# Altium schematic sheet HLib-Comm-CAN_r1.SchDoc
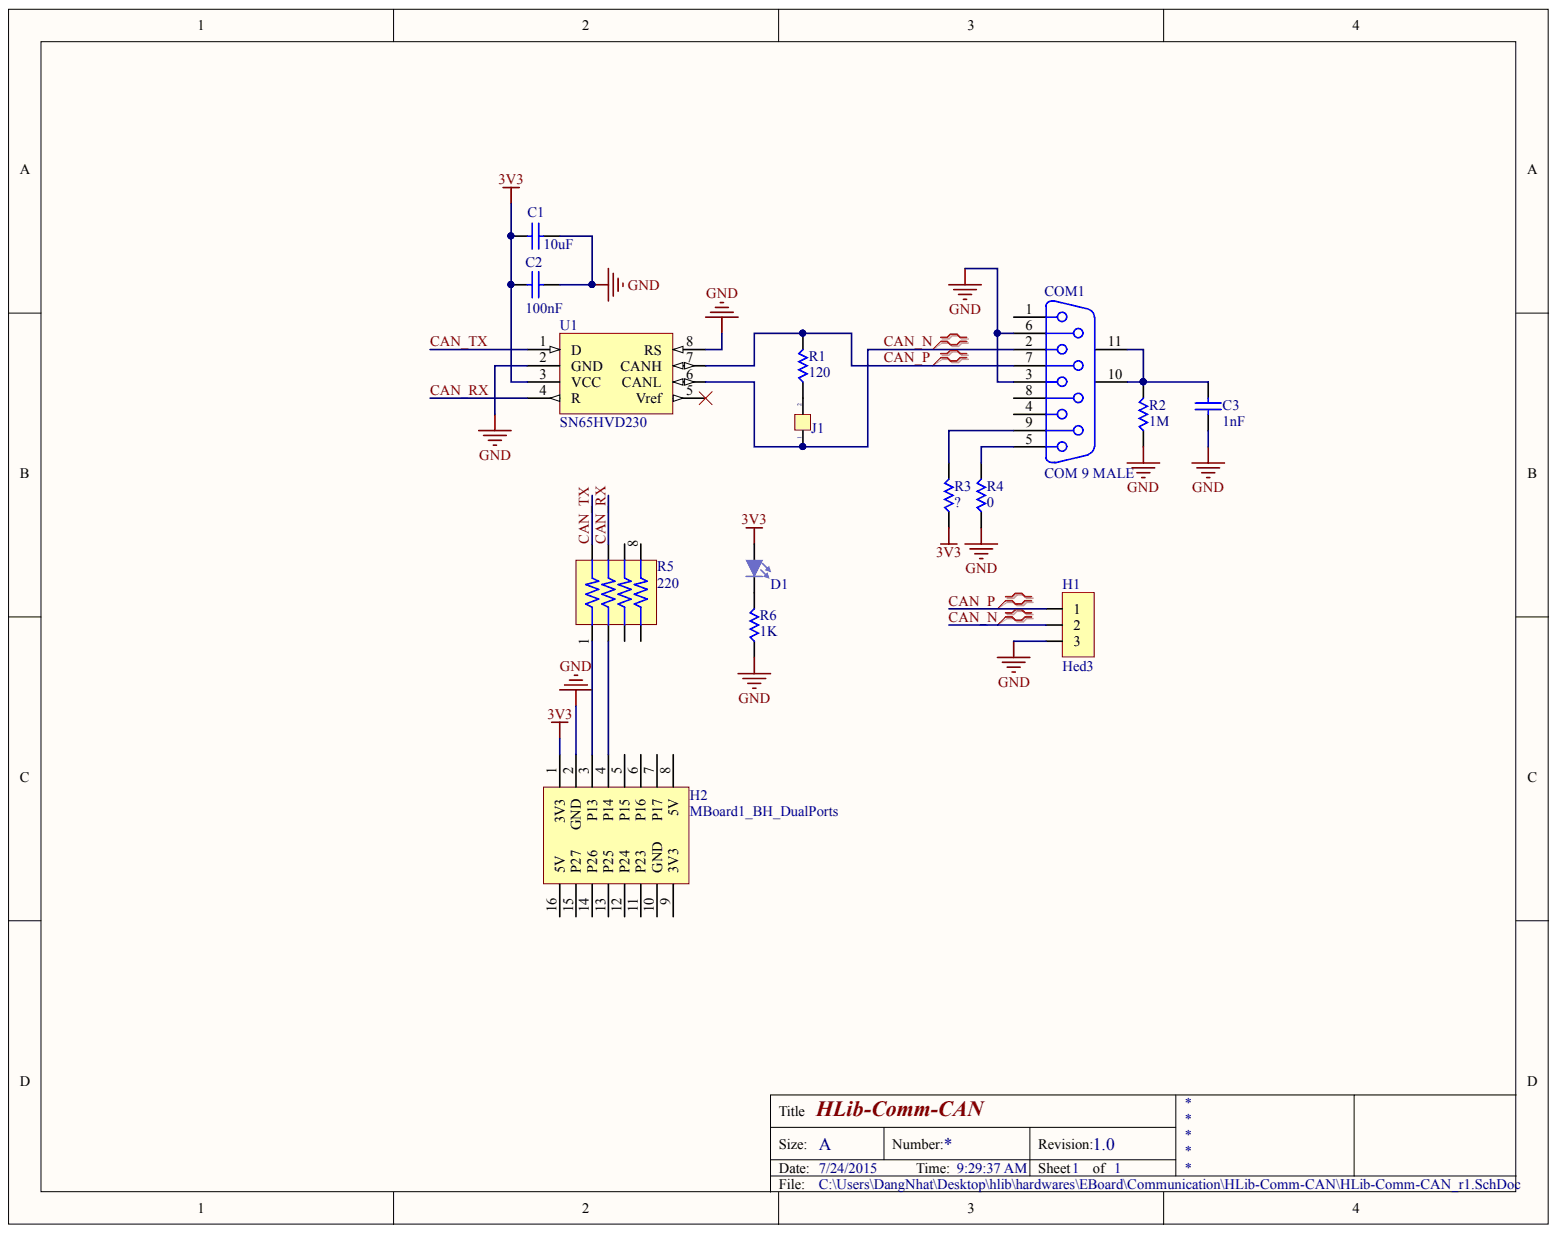
[diagram p0: HLib-Comm-CAN_r1.SchDoc]

## Parts
C1  value 10uF  [SM_0805_C_CERAMIC]  (hidden comment: C_Ceramic)
C2  value 100nF  [SM_0805_C_CERAMIC]  (hidden comment: C_Ceramic)
C3  value 1nF  [SM_0805_C_CERAMIC]  (hidden comment: C_Ceramic)
COM1  COM 9 MALE  value COM 9  [DSB 9-M]
D1  LED  [SM_0805_LED]  (value not drawn)
H1  Hed3  [Hed3]
H2  MBoard1_BH_DualPorts  [MBoard1_BH_DualPorts]
J1  Jumper 2  [HD_MALE_2_100mil_straight]  (value not drawn)
R1  R  value 120  [SM_0805_R]
R2  R  value 1M  [SM_0805_R]
R3  R  [SM_0805_R]
R4  R  value 0  [SM_0805_R]
R5  220  [RA4]
R6  R  value 1K  [SM_0805_R]
U1  SN65HVD230  [SO-8]

## Nets
3V3: C1.1 C2.1 D1.1 H2.1(3V3) R3.1 U1.3(VCC)
GND: C1.2 C2.2 C3.1 COM1.3 COM1.6 H1.3 H2.2(GND) R2.1 R4.1 R6.1 U1.2(GND) U1.8(RS)
CAN_N: COM1.2 H1.2 J1.1 U1.6(CANL)
CAN_P: COM1.7 H1.1 R1.2 U1.7(CANH)
CAN_RX: R5.4 U1.4(R)
CAN_TX: R5.2 U1.1(D)
NetC3_2: C3.2 COM1.10 COM1.11 R2.2
NetCOM1_5: COM1.5 R4.2
NetCOM1_9: COM1.9 R3.2
NetD1_2: D1.2 R6.2
NetH2_3: H2.3(P13) R5.1
NetH2_4: H2.4(P14) R5.3
NetJ1_2: J1.2 R1.1

Not connected: COM1 1 4 8; H2 5(P15) 6(P16) 7(P17) 8(5V) 9(3V3) 10(GND) 11(P23) 12(P24) 13(P25) 14(P26) 15(P27) 16(5V); R5 5 6 7 8; U1 5(Vref)

## Library data of the parts
C1-C3 = C_Ceramic: Non-polarity ceramic capacitor; Component Kind: Standard; LatestRevisionDate: 17-Jul-2002; LatestRevisionNote: Re-released for DXP Platform.; Library Name: HLib_discrete_sch.SchLib; PackageDescription: Radial Cap, Thru-Hole; 2 Leads; 0.3 in Pin Spacing; PackageReference: RAD-0.3; Published: 8-Jun-2000; Publisher: Altium Limited
COM1 = DSB9F: COM 9; Gender: Receptacle; PackageDocument: O; Positions: 9; Component Kind: Standard; DatasheetDocument: 1-Sep-1999; LatestRevisionDate: 17-Jul-2002; LatestRevisionNote: Re-released for DXP Platform.; Orientation: Right Angle; PackageReference: 788750; Published: 15-Jun-2001; Signal Integrity: Connector; Library Name: VioletofSun.SchLib; Publisher: Altium Limited
J1 = JP 2: Value: JP 2; Component Kind: Standard; Description: Jumper 2; Library Name: VioletofSun.SchLib
R1-R4 R6 = R: Resistor; Component Kind: Standard; LatestRevisionDate: 29-May-2009; LatestRevisionNote: IPC-7351 Footprint Added.; Library Name: HLib_discrete_sch.SchLib; PackageDescription: Chip Resistor; PackageReference: J1-0603; Published: 8-Jun-2000; Publisher: Altium Limited
  R3: Value: ?
R5 = RA4: Component Kind: Standard; Library Name: Nhat_Lib.SchLib
D1 = LED: Component Kind: Standard; Description: LED; LatestRevisionDate: 08-Jul-2005; LatestRevisionNote: Mech Layer 1 removed.; Library Name: HLib_discrete_sch.SchLib; PackageDescription: LED; 2 Leads; PackageReference: LED-1; Published: 8-Jun-2000; Publisher: Altium Limited
H1 = Hed3: Component Kind: Standard; Library Name: Nhat_Lib.SchLib
H2 = MBoard1_BH_DualPorts: Component Kind: Standard; Library Name: Nhat_Lib.SchLib
U1 = SN65HVD230: Component Kind: Standard; Library Name: Nhat_Lib.SchLib
